# Altium schematic sheet WS2812_PCB_stack.SchDoc(WS2812_PCB_stack)
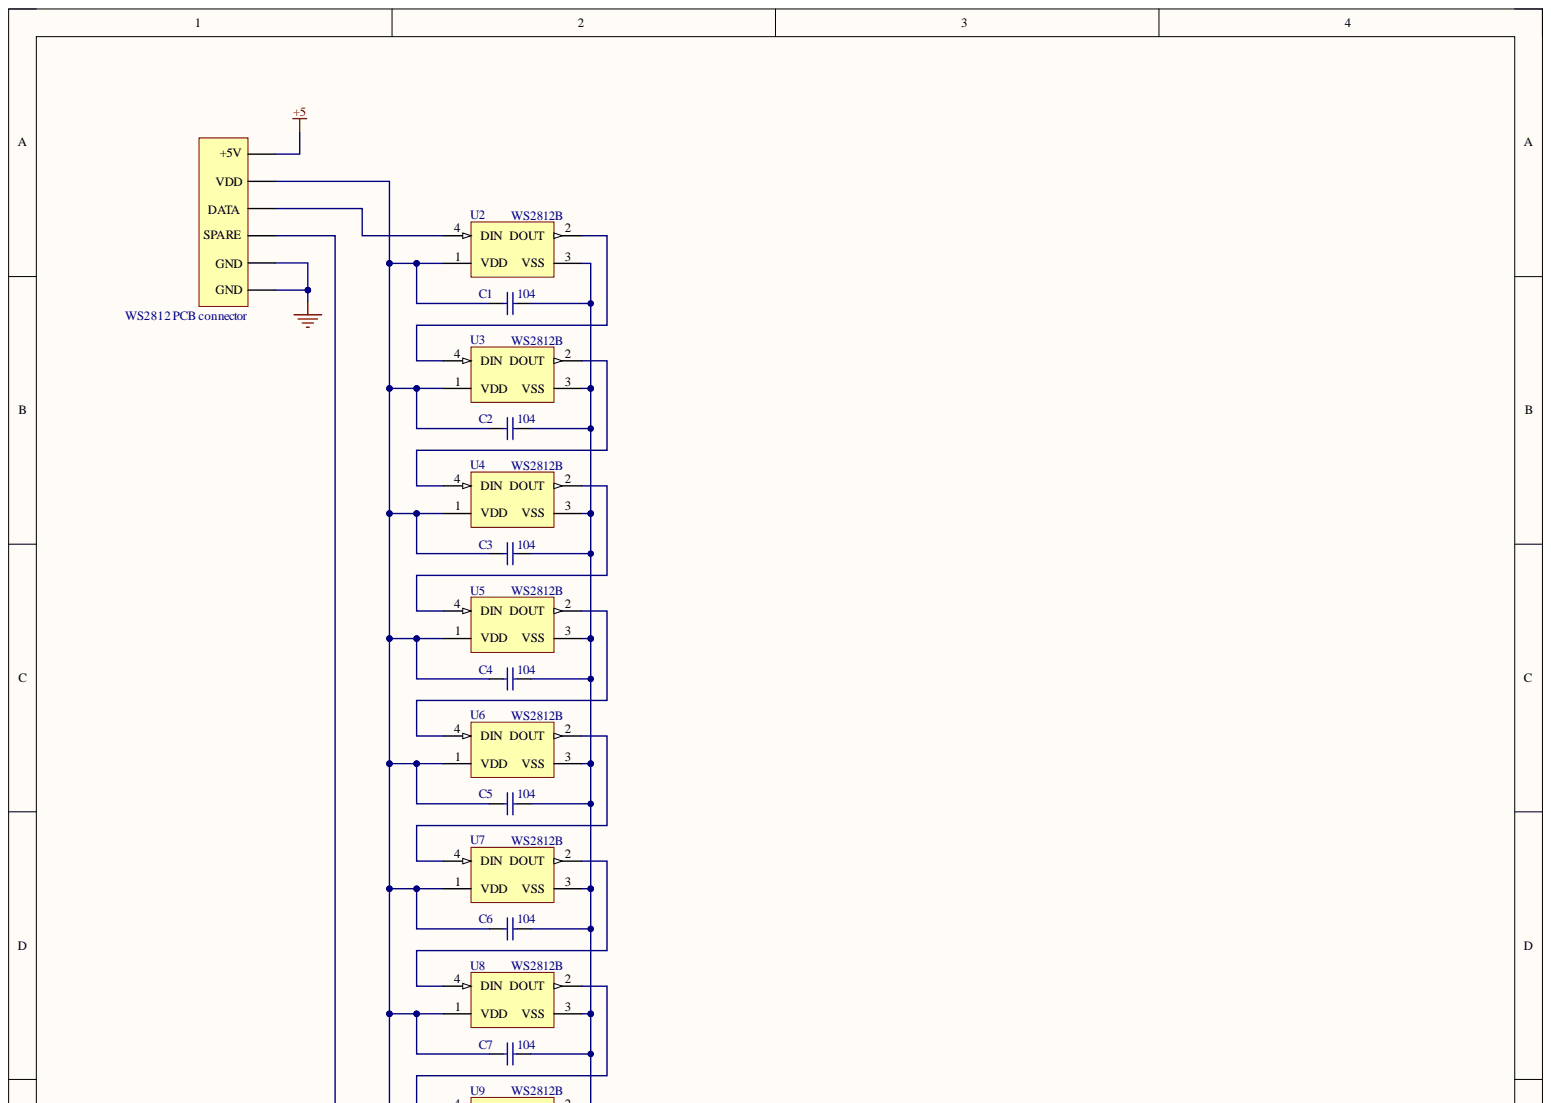
[diagram p0: WS2812_PCB_stack.SchDoc, top]
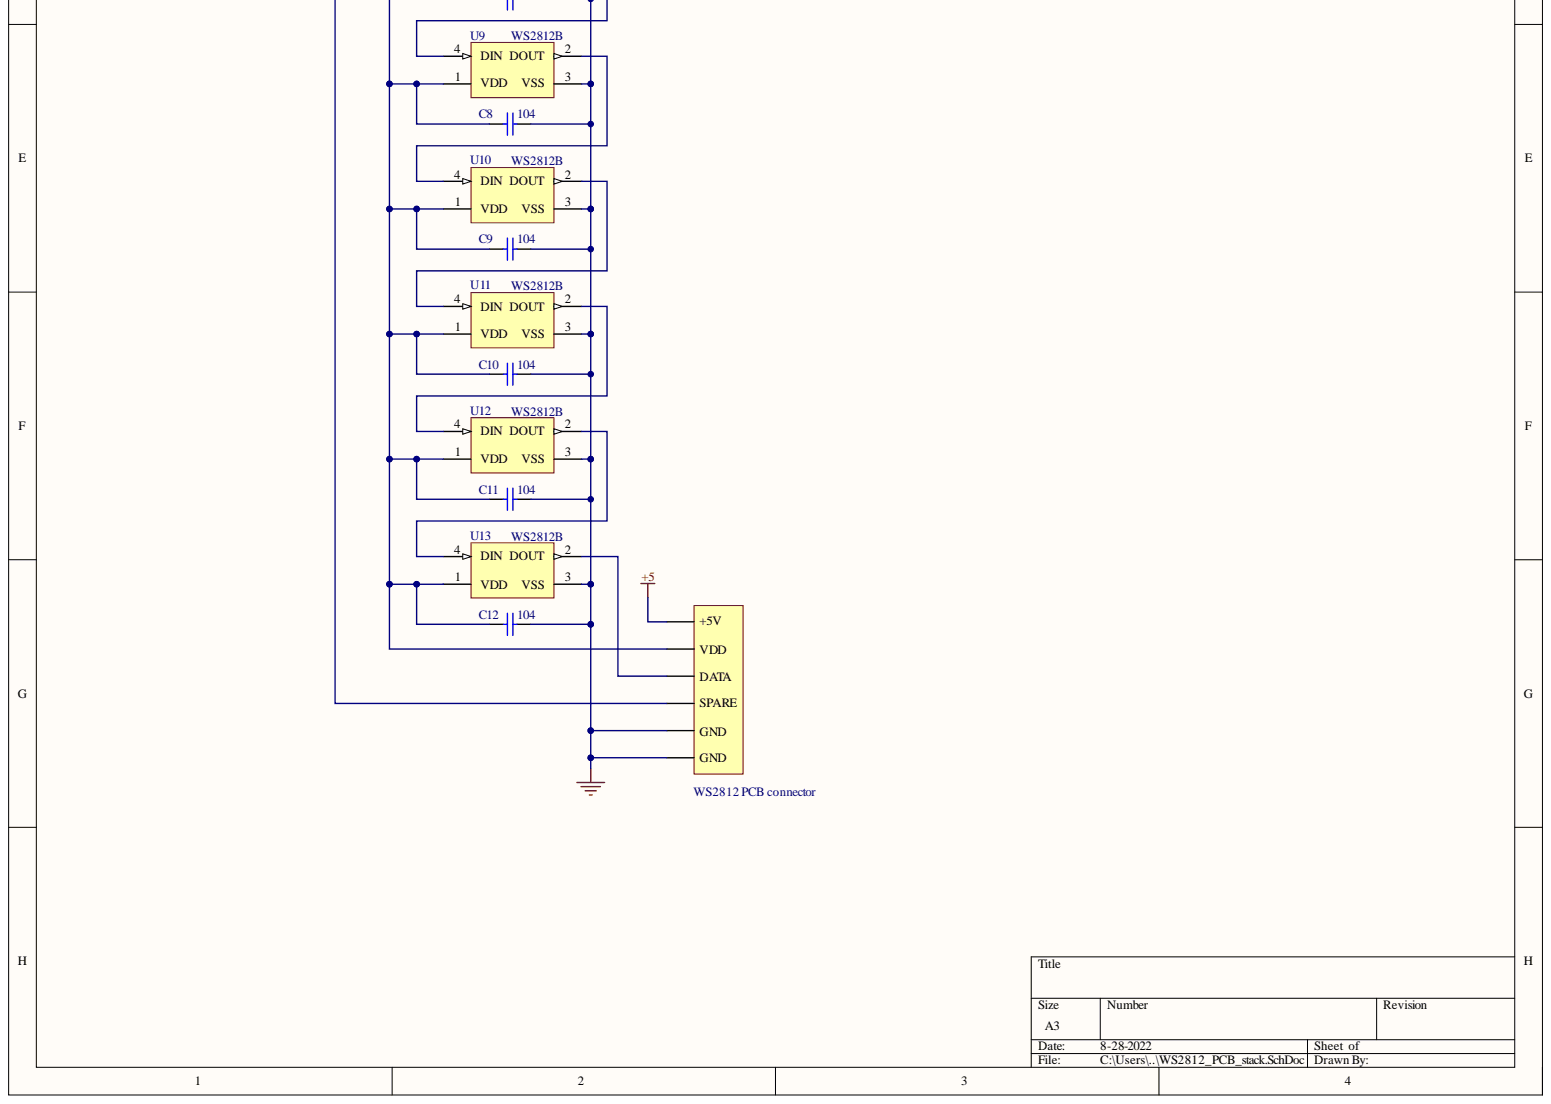
[diagram p1: WS2812_PCB_stack.SchDoc, bottom]

## Parts
C1  104  [CAPC2013X140X45NL15T25]
C2  104  [CAPC2013X140X45NL15T25]
C3  104  [CAPC2013X140X45NL15T25]
C4  104  [CAPC2013X140X45NL15T25]
C5  104  [CAPC2013X140X45NL15T25]
C6  104  [CAPC2013X140X45NL15T25]
C7  104  [CAPC2013X140X45NL15T25]
C8  104  [CAPC2013X140X45NL15T25]
C9  104  [CAPC2013X140X45NL15T25]
C10  104  [CAPC2013X140X45NL15T25]
C11  104  [CAPC2013X140X45NL15T25]
C12  104  [CAPC2013X140X45NL15T25]
J1  WS2812 PCB connector  [WS2812_conn]
J2  WS2812 PCB connector  [WS2812_conn]
U2  WS2812B  [ADA-RGB-1655_V]
U3  WS2812B  [ADA-RGB-1655_V]
U4  WS2812B  [ADA-RGB-1655_V]
U5  WS2812B  [ADA-RGB-1655_V]
U6  WS2812B  [ADA-RGB-1655_V]
U7  WS2812B  [ADA-RGB-1655_V]
U8  WS2812B  [ADA-RGB-1655_V]
U9  WS2812B  [ADA-RGB-1655_V]
U10  WS2812B  [ADA-RGB-1655_V]
U11  WS2812B  [ADA-RGB-1655_V]
U12  WS2812B  [ADA-RGB-1655_V]
U13  WS2812B  [ADA-RGB-1655_V]

## Nets
+5: J1.3(+5V) J2.3(+5V)
GND: C1.2 C2.2 C3.2 C4.2 C5.2 C6.2 C7.2 C8.2 C9.2 C10.2 C11.2 C12.2 J1.5(GND) J1.6(GND) J2.5(GND) J2.6(GND) U2.3(VSS) U3.3(VSS) U4.3(VSS) U5.3(VSS) U6.3(VSS) U7.3(VSS) U8.3(VSS) U9.3(VSS) U10.3(VSS) U11.3(VSS) U12.3(VSS) U13.3(VSS)
NetC1_1: C1.1 C2.1 C3.1 C4.1 C5.1 C6.1 C7.1 C8.1 C9.1 C10.1 C11.1 C12.1 J1.1(VDD) J2.1(VDD) U2.1(VDD) U3.1(VDD) U4.1(VDD) U5.1(VDD) U6.1(VDD) U7.1(VDD) U8.1(VDD) U9.1(VDD) U10.1(VDD) U11.1(VDD) U12.1(VDD) U13.1(VDD)
NetJ1_2: J1.2(DATA) U2.4(DIN)
NetJ1_4: J1.4(SPARE) J2.4(SPARE)
NetJ2_2: J2.2(DATA) U13.2(DOUT)
NetU2_2: U2.2(DOUT) U3.4(DIN)
NetU3_2: U3.2(DOUT) U4.4(DIN)
NetU4_2: U4.2(DOUT) U5.4(DIN)
NetU5_2: U5.2(DOUT) U6.4(DIN)
NetU6_2: U6.2(DOUT) U7.4(DIN)
NetU7_2: U7.2(DOUT) U8.4(DIN)
NetU8_2: U8.2(DOUT) U9.4(DIN)
NetU9_2: U9.2(DOUT) U10.4(DIN)
NetU10_2: U10.2(DOUT) U11.4(DIN)
NetU11_2: U11.2(DOUT) U12.4(DIN)
NetU12_2: U12.2(DOUT) U13.4(DIN)

## Library data of the parts
C1-C12 = CMP-2007-03395-1: Manufacturer: Murata; Part Number: GRM21BR71A106KE51L; Component Kind: Standard; Library Name: Altium Content Vault
J1 J2 = WS2812_conn: Component Kind: Standard; Library Name: Schlib.SchLib
U2-U13 = CMP-2000-05026-1: Intelligent Control LED Integrated Light Source, 3.5 to 5.3 V, -25 to 80 degC, 4-Pin SMD, RoHS, Tape and Reel; Manufacturer: Adafruit-Industries-LLC; Configuration: Discrete; Number of LEDs: 10; Utilized LED: WS2812(5050); Category: Optoelectronics; Color: Red,Green,Blue(RGB); Component Kind: Standard; Controller Type: -; Datasheet URL: http://datasheet.octopart.com/ADA1655-Adafruit-Industries-datasheet-32028139.pdf; Datasheet Version: May-13; Family: Addressable,Specialty; Interface: -; Library Name: Altium Content Vault; Manufacturer URL: https://www.adafruit.com/; Mounting Type: SurfaceMount; Package Description: 4-Pin Surface Mount Device, Body 5 x 5 mm; Package Reference: 1655; Series: Neopixel; Size / Dimension: 5.00mmLx5.00mmW
